# Altium schematic sheet 02_MCU.SchDoc(02_MCU)
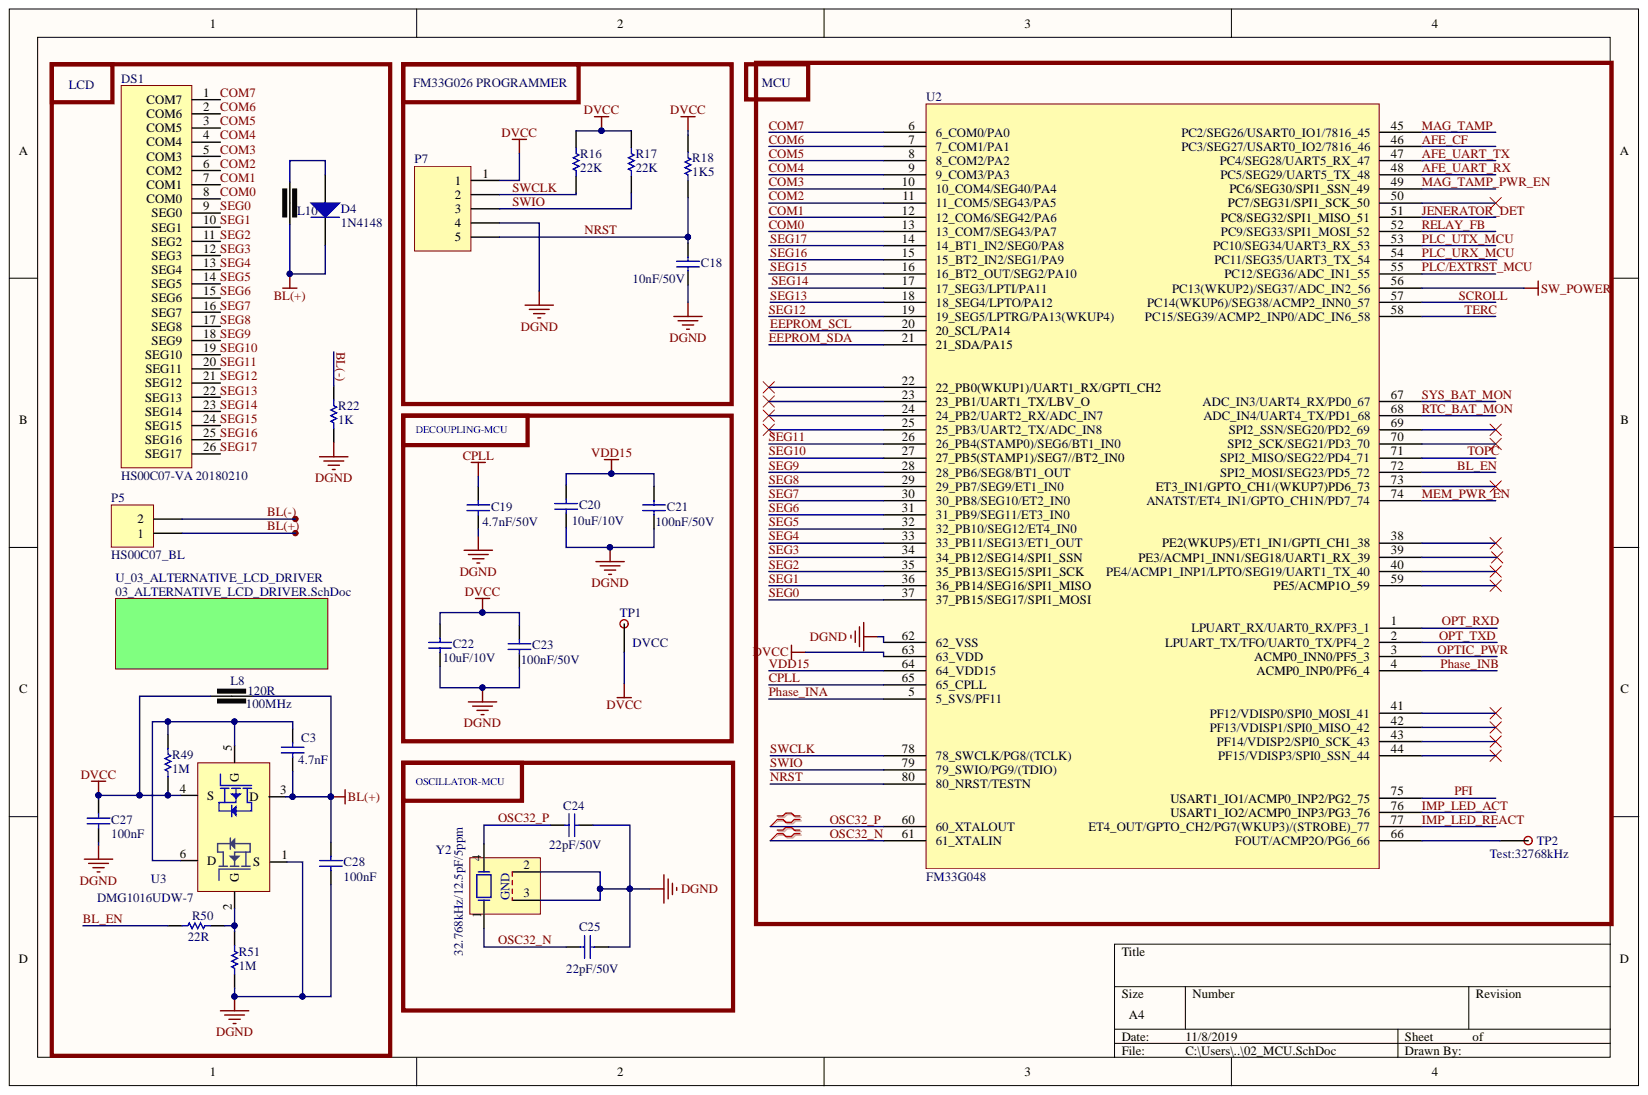
[diagram p0: 02_MCU.SchDoc]

## Parts
C3  Capacitance: 4.7nF  [CAP_0603_V1]  (hidden comment: CAP_SMD)
C18  10nF/50V  [CAP_0603_V1]
C19  4.7nF/50V  [CAP_0603_V1]
C20  10uF/10V  [CAP_0603_V1]
C21  100nF/50V  [CAP_0603_V1]
C22  10uF/10V  [CAP_0603_V1]
C23  100nF/50V  [CAP_0603_V1]
C24  22pF/50V  [CAP_0603_V1]
C25  22pF/50V  [CAP_0603_V1]
C27  Capacitance: 100nF  [CAP_0603_V1]  (hidden comment: CAP_SMD)
C28  Capacitance: 100nF  [CAP_0603_V1]  (hidden comment: CAP_SMD)
D4  004_Value: 1N4148  [SOD-123]  (hidden comment: DIODE)
DS1  value HS00C07-VA 20180210  [HS00C07-VA-20171019]  (hidden comment: HS00C07-VHB 20180830)
L8  Test Frequency: 100MHz  Impedance: 120R  [FERRITE-BEAD_0603_V1]  (hidden comment: FERRITE BEAD)
L10  FERRITE BEAD  [FERRITE-BEAD_0603_V1]  (value not drawn)
P5  value HS00C07_BL  [HS00C07-VA-20171019_BL]  (hidden comment: HEADER-2PIN)
P7  HEADER_1X5  [HEADER_5_PIN_2X3_V1_2.54]  (value not drawn)
R16  22K  [RES_0603_V1]
R17  22K  [RES_0603_V1]
R18  1K5  [RES_0603_V1]
R22  004_Value: 1K  [RES_1206_V1]  (hidden comment: RES_SMD)
R49  004_Value: 1M  [RES_0603_V1]  (hidden comment: RES_SMD)
R50  004_Value: 22R  [RES_0603_V1]  (hidden comment: RES_SMD)
R51  004_Value: 1M  [RES_0603_V1]  (hidden comment: RES_SMD)
TP1  004_Value: DVCC  [TEST-PAD_2.0X2.0_ROUND - No_3D_Step]  (hidden comment: TEST_POINT)
TP2  004_Value: Test:32768kHz  [TEST-PAD_2.0X2.0_ROUND - No_3D_Step]  (hidden comment: TEST_POINT)
U2  MCU, FUDAN, CORTEX-M0  [LQFP-80N]  (value not drawn)
U3  DMG1016UDW-7  [SOT363(TSSOP6)_TSOP65P210X110-6N]
Y2  004_Value: 32.768kHz/12.5pF/5ppm  [XTAL_THT_SMD_TUNING_FORK]  (hidden comment: R26-32.768)

## Nets
DGND: C18.1 C19.1 C20.1 C21.1 C22.1 C23.1 C24.2 C25.2 C27.1 C28.1 P7.4 R22.1 R51.1 U2.62(62_VSS) U3.1(S) Y2.2 Y2.3
DVCC: C22.2 C23.2 C27.2 L8.1 P7.1 R16.2 R17.2 R18.2 R49.1 TP1.1 U2.63(63_VDD) U3.4(S)
SW_POWER: U2.56(PC13(WKUP2)/SEG37/ADC_IN2_56)
AFE_CF: U2.46(PC3/SEG27/USART0_IO2/7816_46)
AFE_UART_RX: U2.48(PC5/SEG29/UART5_TX_48)
AFE_UART_TX: U2.47(PC4/SEG28/UART5_RX_47)
BL(+): C3.1 C28.2 D4.2 L8.2 L10.2 P5.1 U3.3(D)
BL(-): P5.2 R22.2
BL_EN: R50.1 U2.72(SPI2_MOSI/SEG23/PD5_72)
COM0: DS1.8(COM0) U2.13(13_COM7/SEG43/PA7)
COM1: DS1.7(COM1) U2.12(12_COM6/SEG42/PA6)
COM2: DS1.6(COM2) U2.11(11_COM5/SEG43/PA5)
COM3: DS1.5(COM3) U2.10(10_COM4/SEG40/PA4)
COM4: DS1.4(COM4) U2.9(9_COM3/PA3)
COM5: DS1.3(COM5) U2.8(8_COM2/PA2)
COM6: DS1.2(COM6) U2.7(7_COM1/PA1)
COM7: DS1.1(COM7) U2.6(6_COM0/PA0)
CPLL: C19.2 U2.65(65_CPLL)
EEPROM_SCL: U2.20(20_SCL/PA14)
EEPROM_SDA: U2.21(21_SDA/PA15)
IMP_LED_ACT: U2.76(USART1_IO2/ACMP0_INP3/PG3_76)
IMP_LED_REACT: U2.77(ET4_OUT/GPTO_CH2/PG7(WKUP3)/(STROBE)_77)
JENERATOR_DET: U2.51(PC8/SEG32/SPI1_MISO_51)
MAG_TAMP: U2.45(PC2/SEG26/USART0_IO1/7816_45)
MAG_TAMP_PWR_EN: U2.49(PC6/SEG30/SPI1_SSN_49)
MEM_PWR_EN: U2.74(ANATST/ET4_IN1/GPTO_CH1N/PD7_74)
NRST: C18.2 P7.5 R18.1 U2.80(80_NRST/TESTN)
OPT_RXD: U2.1(LPUART_RX/UART0_RX/PF3_1)
OPT_TXD: U2.2(LPUART_TX/TFO/UART0_TX/PF4_2)
OPTIC_PWR: U2.3(ACMP0_INN0/PF5_3)
OSC32_N: C25.1 U2.61(61_XTALIN) Y2.1
OSC32_P: C24.1 U2.60(60_XTALOUT) Y2.4
PFI: U2.75(USART1_IO1/ACMP0_INP2/PG2_75)
Phase_INA: U2.5(5_SVS/PF11)
Phase_INB: U2.4(ACMP0_INP0/PF6_4)
PLC/EXTRST_MCU: U2.55(PC12/SEG36/ADC_IN1_55)
PLC_URX_MCU: U2.54(PC11/SEG35/UART3_TX_54)
PLC_UTX_MCU: U2.53(PC10/SEG34/UART3_RX_53)
RELAY_FB: U2.52(PC9/SEG33/SPI1_MOSI_52)
RTC_BAT_MON: U2.68(ADC_IN4/UART4_TX/PD1_68)
SCROLL: U2.57(PC14(WKUP6)/SEG38/ACMP2_INN0_57)
SEG0: DS1.9(SEG0) U2.37(37_PB15/SEG17/SPI1_MOSI)
SEG1: DS1.10(SEG1) U2.36(36_PB14/SEG16/SPI1_MISO)
SEG2: DS1.11(SEG2) U2.35(35_PB13/SEG15/SPI1_SCK)
SEG3: DS1.12(SEG3) U2.34(34_PB12/SEG14/SPI1_SSN)
SEG4: DS1.13(SEG4) U2.33(33_PB11/SEG13/ET1_OUT)
SEG5: DS1.14(SEG5) U2.32(32_PB10/SEG12/ET4_IN0)
SEG6: DS1.15(SEG6) U2.31(31_PB9/SEG11/ET3_IN0)
SEG7: DS1.16(SEG7) U2.30(30_PB8/SEG10/ET2_IN0)
SEG8: DS1.17(SEG8) U2.29(29_PB7/SEG9/ET1_IN0)
SEG9: DS1.18(SEG9) U2.28(28_PB6/SEG8/BT1_OUT)
SEG10: DS1.19(SEG10) U2.27(27_PB5(STAMP1)/SEG7//BT2_IN0)
SEG11: DS1.20(SEG11) U2.26(26_PB4(STAMP0)/SEG6/BT1_IN0)
SEG12: DS1.21(SEG12) U2.19(19_SEG5/LPTRG/PA13(WKUP4))
SEG13: DS1.22(SEG13) U2.18(18_SEG4/LPTO/PA12)
SEG14: DS1.23(SEG14) U2.17(17_SEG3/LPTI/PA11)
SEG15: DS1.24(SEG15) U2.16(16_BT2_OUT/SEG2/PA10)
SEG16: DS1.25(SEG16) U2.15(15_BT2_IN2/SEG1/PA9)
SEG17: DS1.26(SEG17) U2.14(14_BT1_IN2/SEG0/PA8)
SWCLK: P7.2 R16.1 U2.78(78_SWCLK/PG8/(TCLK))
SWIO: P7.3 R17.1 U2.79(79_SWIO/PG9/(TDIO))
SYS_BAT_MON: U2.67(ADC_IN3/UART4_RX/PD0_67)
TERC: U2.58(PC15/SEG39/ACMP2_INP0/ADC_IN6_58)
TOPC: U2.71(SPI2_MISO/SEG22/PD4_71)
VDD15: C20.2 C21.2 U2.64(64_VDD15)
NetC3_2: C3.2 R49.2 U3.5(G) U3.6(D)
NetD4_1: D4.1 L10.1
NetR50_2: R50.2 R51.2 U3.2(G)
NetTP2_1: TP2.1 U2.66(FOUT/ACMP2O/PG6_66)

Not connected: U2 22(22_PB0(WKUP1)/UART1_RX/GPTI_CH2) 23(23_PB1/UART1_TX/LBV_O) 24(24_PB2/UART2_RX/ADC_IN7) 25(25_PB3/UART2_TX/ADC_IN8) 38(PE2(WKUP5)/ET1_IN1/GPTI_CH1_38) 39(PE3/ACMP1_INN1/SEG18/UART1_RX_39) 40(PE4/ACMP1_INP1/LPTO/SEG19/UART1_TX_40) 41(PF12/VDISP0/SPI0_MOSI_41) 42(PF13/VDISP1/SPI0_MISO_42) 43(PF14/VDISP2/SPI0_SCK_43) 44(PF15/VDISP3/SPI0_SSN_44) 50(PC7/SEG31/SPI1_SCK_50) 59(PE5/ACMP1O_59) 69(SPI2_SSN/SEG20/PD2_69) 70(SPI2_SCK/SEG21/PD3_70) 73(ET3_IN1/GPTO_CH1/(WKUP7)PD6_73)

## Library data of the parts
C3 = CAP_SMD: 017_Manufacturer-1 PN: CL10B472KB8SFNC; 016_Manufacturer-1: Samsung; Voltage Rating: 50V; Tolerance: 10%; Parameter Name: Tape and Reel; Dielectric: X7R; 006_SMT/THT: Surface Mount; 001_Description: CAP CER 4700PF 50V X7R 0603; Width: 0.031inch; Thickness: 0.035inch; Supplier Part Number 1: CL10B472KB8SFNC-REEL; Supplier 1: Future Electronics; Stock 1: 0; Radiation Hardening: No; Package Quantity: 1; Min Operating Temperature: -55°C; Max Operating Temperature: 125°C; Library Name: ElectricMeter.SchLib; Length: 0.063inch; Height: 0.8mm; Features: Soft Termination; Depth: 0.8mm; ComponentLink1URL: http://www.samsungsem.com/kr/support/product-search/mlcc/CL10B472KB8SFNC.jsp?src-supplier=Digi-Key; ComponentLink1Description: http://www.samsungsem.com/kr/support/product-search/mlcc/CL10B472KB8SFNC.jsp?src-supplier=Digi-Key; Component Kind: Standard; Case Code (Metric): 1608; Case Code (Imperial): 0603; Case/Package: 0603
C18 = CAP_SMD: MLCC, 10nF, 50V, X7R, 0603, %10; 017_Manufacturer-1 PN: GRM188R71H103KA01D; 016_Manufacturer-1: Murata; Tolerance: 10%; Parameter Name: Tape and Reel; Material: Ceramic; Insulation Resistance: 10GR; Width: 0.031inch; Voltage Rating (DC): 50V; Voltage Rating: 50V; Voltage: 50V; Thickness: 0.035inch; Termination: SMD/SMT; Supplier Part Number 1: GRM188R71H103KA01D; Supplier 1: Verical; Stock 1: 0; REACH SVHC: Unknown; Package Quantity: 1; Number of Pins: 2; Mount: Surface Mount; Min Operating Temperature: -55°C; Max Operating Temperature: 125°C; Library Name: SCH_LIB.SCHLIB; Length: 1.6mm; Lead Free: Lead Free; Height: 0.8mm; Dielectric Material: Ceramic; Dielectric: X7R; Depth: 0.8mm; ComponentLink6URL: https://produktinfo.conrad.com/datenblaetter/450000-474999/451715-da-01-en-VS_KONDENSATOR_0603.pdf?src-supplier=Conrad; ComponentLink6Description: https://produktinfo.conrad.com/datenblaetter/450000-474999/451715-da-01-en-VS_KONDENSATOR_0603.pdf?src-supplier=Conrad; ComponentLink5URL: https://www.distrelec.biz/Web/Downloads/_t/ds/murata_MLCC_eng_tds.pdf?mime=application%2Fpdf&src-supplier=Distrelec; ComponentLink5Description: https://www.distrelec.biz/Web/Downloads/_t/ds/murata_MLCC_eng_tds.pdf?mime=application%2Fpdf&src-supplier=Distrelec; ComponentLink4URL: http://www.farnell.com/datasheets/2047844.pdf?src-supplier=Element14; ComponentLink4Description: http://www.farnell.com/datasheets/2047844.pdf?src-supplier=Element14; ComponentLink3URL: http://www.farnell.com/datasheets/4360.pdf?src-supplier=Element14; ComponentLink3Description: http://www.farnell.com/datasheets/4360.pdf?src-supplier=Element14; ComponentLink2URL: http://uk.rs-online.com/webdocs/0030/0900766b8003061f.pdf?src-supplier=RSComponents; ComponentLink2Description: http://uk.rs-online.com/webdocs/0030/0900766b8003061f.pdf?src-supplier=RSComponents; ComponentLink1URL: https://www.murata.com/~/media/webrenewal/support/library/catalog/products/capacitor/mlcc/c02e.ashx?la=en-us&src-supplier=Digi-Key; ComponentLink1Description: https://www.murata.com/~/media/webrenewal/support/library/catalog/products/capacitor/mlcc/c02e.ashx?la=en-us&src-supplier=Digi-Key; Component Kind: Standard; Case Code (Metric): 1608; Case Code (Imperial): 0603; Case/Package: 0603; Capacitance: 10nF
C19 = CAP_SMD: MLCC, 4.7nF, 50V, X7R, 0603, %10; 017_Manufacturer-1 PN: CL10B472JB8NNNC; 016_Manufacturer-1: Samsung; Tolerance: 5%; Parameter Name: Tape and Reel; Material: Ceramic; Width: 0.031inch; Voltage Rating (DC): 50V; Voltage Rating: 50V; Voltage: 50V; Thickness: 0.035inch; Supplier Part Number 1: CL10B472JB8NNNC; Supplier 1: Arrow; Stock 1: 319584; Radiation Hardening: No; Pricing 1: 4000=0.0063, 8000=0.0057, 12000=0.0052, 1=0.0049, 25=0.0047 (USD); Package Quantity: 1; Mount: Surface Mount; Min Operating Temperature: -55°C; Max Operating Temperature: 125°C; Library Name: SCH_LIB.SCHLIB; Length: 1.6mm; Lead Free: Lead Free; Height: 0.8mm; Dielectric: X7R; Depth: 0.8mm; Contact Plating: Tin; ComponentLink5URL: https://produktinfo.conrad.com/datenblaetter/450000-474999/457717-da-01-en-VS_KONDENSATOR_0603.pdf?src-supplier=Conrad; ComponentLink5Description: https://produktinfo.conrad.com/datenblaetter/450000-474999/457717-da-01-en-VS_KONDENSATOR_0603.pdf?src-supplier=Conrad; ComponentLink4URL: https://www.distrelec.biz/Web/Downloads/_e/ng/MLCC_CatalogDownload2013_ENG.pdf?mime=application%2Fpdf&src-supplier=Distrelec; ComponentLink4Description: https://www.distrelec.biz/Web/Downloads/_e/ng/MLCC_CatalogDownload2013_ENG.pdf?mime=application%2Fpdf&src-supplier=Distrelec; ComponentLink3URL: http://www1.futureelectronics.com/doc/SAMSUNG%20PASSIVE/CL05B104KQ5NNNC.pdf?src-supplier=Future+Electronics; ComponentLink3Description: http://www1.futureelectronics.com/doc/SAMSUNG%20PASSIVE/CL05B104KQ5NNNC.pdf?src-supplier=Future+Electronics; ComponentLink2URL: https://www.verical.com/server-webapp/html/getDatasheet?partID=666231&src-supplier=Verical; ComponentLink2Description: https://www.verical.com/server-webapp/html/getDatasheet?partID=666231&src-supplier=Verical; ComponentLink1URL: https://media.digikey.com/pdf/Data%20Sheets/Samsung%20PDFs/CL_Series_MLCC_ds.pdf?src-supplier=Digi-Key; ComponentLink1Description: https://media.digikey.com/pdf/Data%20Sheets/Samsung%20PDFs/CL_Series_MLCC_ds.pdf?src-supplier=Digi-Key; Component Kind: Standard; Case Code (Metric): 1608; Case Code (Imperial): 0603; Case/Package: 0603; Capacitance: 4.7nF
C20 C22 = CAP_SMD: 10µF ±10% 10V Ceramic Capacitor X5R 0603 (1608 Metric); 017_Manufacturer-1 PN: GRM188R61A106KE69D; 016_Manufacturer-1: Murata; Parameter Name: Tape and Reel; Capacitance: 10uF; Width: 0.031inch; Voltage Rating (DC): 10V; Voltage Rating: 10V; Voltage: 10V; Tolerance: 10%; Thickness: 0.037inch; Supplier Part Number 1: GRM188R61A106KE69D; Supplier 1: Arrow; Stock 1: 2410; REACH SVHC: No SVHC; Pricing 1: 1=0.0335 (USD); Mount: Surface Mount; Min Operating Temperature: -55°C; Max Operating Temperature: 85°C; Library Name: SCH_LIB.SCHLIB; Length: 1.6mm; Lead Free: Lead Free; Height: 0.8mm; Dielectric: X5R; Depth: 0.8mm; Component Kind: Standard; Case Code (Metric): 1608; Case Code (Imperial): 0603; Case/Package: 0603
C21 C23 = CAP_SMD: CAP CER 0.1UF 50V X7R 0603; 017_Manufacturer-1 PN: CL10B104KB8NNNC; 016_Manufacturer-1: Samsung; Tolerance: 10%; Parameter Name: Tape and Reel; Material: Ceramic; Capacitance: 100nF; Width: 0.031inch; Voltage Rating (DC): 50V; Voltage Rating: 50V; Voltage: 50V; Thickness: 0.035inch; Supplier Part Number 1: CL10B104KB8NNNC; Radiation Hardening: No; Package Quantity: 1; Mount: Surface Mount; Min Operating Temperature: -55°C; Max Operating Temperature: 125°C; Library Name: SCH_LIB.SCHLIB; Length: 1.6mm; Lead Free: Lead Free; Height: 0.8mm; Dielectric: X7R; Depth: 0.8mm; Contact Plating: Tin; ComponentLink3URL: https://produktinfo.conrad.com/datenblaetter/450000-474999/456651-da-01-en-VS_KONDENSATOR_0605.pdf?src-supplier=Conrad; ComponentLink3Description: https://produktinfo.conrad.com/datenblaetter/450000-474999/456651-da-01-en-VS_KONDENSATOR_0605.pdf?src-supplier=Conrad; ComponentLink2URL: https://www.verical.com/server-webapp/html/getDatasheet?partID=89097&src-supplier=Verical; ComponentLink2Description: https://www.verical.com/server-webapp/html/getDatasheet?partID=89097&src-supplier=Verical; ComponentLink1URL: http://www.samsungsem.com/kr/support/product-search/mlcc/CL10B104KB8NNNC.jsp?src-supplier=Digi-Key; ComponentLink1Description: http://www.samsungsem.com/kr/support/product-search/mlcc/CL10B104KB8NNNC.jsp?src-supplier=Digi-Key; Component Kind: Standard; Case Code (Metric): 1608; Case Code (Imperial): 0603; Case/Package: 0603
  C21: Supplier 1: Arrow; Stock 1: 16987458; Pricing 1: 4000=0.0047, 8000=0.0043, 12000=0.0032, 1=0.0352, 10=0.0258, 25=0.0233, 100=0.02, 250=0.018 (USD)
  C23: Supplier 1: Arrow Europe; Stock 1: 0
C24 C25 = CAP_SMD: 22pF ±5% 50V Ceramic Capacitor C0G, NP0 0603 (1608 Metric); 017_Manufacturer-1 PN: GRM1885C1H220JA01D; 016_Manufacturer-1: Murata; Tolerance: 10%; Temperature Coefficient: 30ppm/°C; Parameter Name: Tape and Reel; Insulation Resistance: 10GR; Width: 0.031inch; Voltage Rating (DC): 50V; Voltage Rating: 50V; Voltage: 50V; Thickness: 0.035inch; Termination: SMD/SMT; Supplier Part Number 1: GRM1885C1H220JA01D**MULT; Supplier 1: Arrow; Stock 1: 0; REACH SVHC: No SVHC; Radiation Hardening: No; Package Quantity: 1; Number of Pins: 2; Mount: Surface Mount; Min Operating Temperature: -55°C; Max Operating Temperature: 125°C; Material: Ceramic; Library Name: SCH_LIB.SCHLIB; Length: 1.6mm; Lead Free: Lead Free; Height: 0.8mm; Dielectric Material: Ceramic; Dielectric: C0G; Depth: 0.8mm; ComponentLink4URL: http://uk.rs-online.com/webdocs/1423/0900766b81423d62.pdf?src-supplier=RSComponents; ComponentLink4Description: http://uk.rs-online.com/webdocs/1423/0900766b81423d62.pdf?src-supplier=RSComponents; ComponentLink3URL: http://components.arrow.com/part/dataview/39140722S3442535N2606?src-supplier=Arrow; ComponentLink3Description: http://components.arrow.com/part/dataview/39140722S3442535N2606?src-supplier=Arrow; ComponentLink2URL: https://www.distrelec.biz/Web/Downloads/_t/ds/murata_MLCC_eng_tds.pdf?mime=application%2Fpdf&src-supplier=Distrelec; ComponentLink2Description: https://www.distrelec.biz/Web/Downloads/_t/ds/murata_MLCC_eng_tds.pdf?mime=application%2Fpdf&src-supplier=Distrelec; ComponentLink1URL: https://www.murata.com/~/media/webrenewal/support/library/catalog/products/capacitor/mlcc/c02e.ashx?la=en-us&src-supplier=Digi-Key; ComponentLink1Description: https://www.murata.com/~/media/webrenewal/support/library/catalog/products/capacitor/mlcc/c02e.ashx?la=en-us&src-supplier=Digi-Key; Component Kind: Standard; Case Code (Metric): 1608; Case Code (Imperial): 0603; Case/Package: 0603; Capacitance: 22pF
C27 C28 = CAP_SMD: MLCC; 100nF; 50V; X7R; ±10%; SMD; 0603; -55÷125°C; 017_Manufacturer-1 PN: CL10B104KB8NNNC; 016_Manufacturer-1: Samsung; Parameter Name: Tape and Reel; Material: Ceramic; 006_SMT/THT: Surface Mount; 001_Description: Capacitor: ceramic; MLCC; 100nF; 50V; X7R; ±10%; SMD; 0603; -55÷125°C; Width: 0.031inch; Voltage Rating (DC): 50V; Voltage Rating: 50V; Voltage: 50V; Tolerance: 10%; Thickness: 0.035inch; Supplier Part Number 1: 766-5443; Supplier 1: RSComponents; Stock 1: 0; Radiation Hardening: No; Package Quantity: 1; Min Operating Temperature: -55°C; Max Operating Temperature: 125°C; Library Name: ElectricMeter.SchLib; Length: 1.6mm; Lead Free: Lead Free; Height: 0.8mm; Dielectric: X7R; Depth: 0.8mm; Contact Plating: Tin; ComponentLink3URL: https://produktinfo.conrad.com/datenblaetter/450000-474999/456651-da-01-en-VS_KONDENSATOR_0605.pdf?src-supplier=Conrad; ComponentLink3Description: https://produktinfo.conrad.com/datenblaetter/450000-474999/456651-da-01-en-VS_KONDENSATOR_0605.pdf?src-supplier=Conrad; ComponentLink2URL: https://www.verical.com/server-webapp/html/getDatasheet?partID=89097&src-supplier=Verical; ComponentLink2Description: https://www.verical.com/server-webapp/html/getDatasheet?partID=89097&src-supplier=Verical; ComponentLink1URL: http://www.samsungsem.com/kr/support/product-search/mlcc/CL10B104KB8NNNC.jsp?src-supplier=Digi-Key; ComponentLink1Description: http://www.samsungsem.com/kr/support/product-search/mlcc/CL10B104KB8NNNC.jsp?src-supplier=Digi-Key; Component Kind: Standard; Case Code (Metric): 1608; Case Code (Imperial): 0603; Case/Package: 0603
D4 = DIODE: Diode, Fast Switching, 100V, 300mA, SOD123; 019_Manufacturer-1 Digi-Key PN: 1N4148W-FDITR-ND; 017_Manufacturer-1 PN: 1N4148W-7-F; 016_Manufacturer-1: Diodes; 007_Status: MP; 006_SMT/THT: SMT; 005_Process: SMD_Mounter; Library Name: BM.10.LC02.SCHLIB; Component Kind: Standard; 008_Package: SOD123; 001_Designation: Diode
DS1 = HS00C07-VA-20171019: LCD, Glass, HS00C07-VHB 20180830 foam 2.5mm; Manufacturer: HT Display; SMT/THT: THT; Model 4: BM32; Model 3: BM31; Model 2: BM22; Model 1: BM21; Status: MP; Project: -; Manufacturer PN 2: -; Manufacturer PN: HS00C07-VHB 20180830; Manufacturer 2: -; Library Name: ElectricMeter.SchLib; Designation: LCD; Component Kind: Standard
L8 = FERRITE BEAD: Inductor, Ferrite Bead, 120R@100Mhz, Power Line, 2A; 048_Manufacturer-2 PN: CIC10P121NC; 047_Manufacturer-2: SAMSUNG; 017_Manufacturer-1 PN: BLM18PG121SN1D; 016_Manufacturer-1: Murata; Tolerance: 25%; Shielding: Unshielded; Parameter Name: Tape and Reel; Number of Lines: 1; Material: Steel,Ferrite; DC Resistance (DCR): 50mR; 001_Description: MURATA - BLM18PG121SN1D - FERRITE BEAD, 120 OHM, 2A, 0603; Width: 0.8mm; Termination: SMD/SMT; Supplier Part Number 1: 724-1380; Supplier 1: RSComponents; Stock 1: 0; REACH SVHC: No SVHC; Radiation Hardening: No; Package Quantity: 1; Number of Pins: 2; Number of Circuits: 1; Min Operating Temperature: -55°C; Max Operating Temperature: 125°C; Max DC Current: 2A; Max Current Rating: 2A; Library Name: ElectricMeter.SchLib; Length: 1.6mm; Lead Free: Lead Free; Height: 0.8mm; Frequency: 100MHz; Depth: 0.8mm; DC Current: 2A; Current Rating: 2A; Core Material: Ferrite; ComponentLink11URL: http://www.produktinfo.conrad.com/datenblaetter/1100000-1199999/001172297-da-01-en-DROSSEL_0603_120R_1_6X0_8MM_4000ST.pdf?src-supplier=Conrad; ComponentLink11Description: http://www.produktinfo.conrad.com/datenblaetter/1100000-1199999/001172297-da-01-en-DROSSEL_0603_120R_1_6X0_8MM_4000ST.pdf?src-supplier=Conrad; ComponentLink10URL: http://www.produktinfo.conrad.com/datenblaetter/425000-449999/442365-da-01-en-CHIP_DROSSEL_0603_120R_2000MA_1_6X0_8MM.pdf?src-supplier=Conrad; ComponentLink10Description: http://www.produktinfo.conrad.com/datenblaetter/425000-449999/442365-da-01-en-CHIP_DROSSEL_0603_120R_2000MA_1_6X0_8MM.pdf?src-supplier=Conrad; ComponentLink9URL: http://www.farnell.com/datasheets/1740433.pdf?src-supplier=Avnet+Europe; ComponentLink9Description: http://www.farnell.com/datasheets/1740433.pdf?src-supplier=Avnet+Europe; ComponentLink8URL: http://www.farnell.com/datasheets/1740433.pdf?src-supplier=Jameco; ComponentLink8Description: http://www.farnell.com/datasheets/1740433.pdf?src-supplier=Jameco; ComponentLink7URL: http://uk.rs-online.com/webdocs/13d6/0900766b813d688c.pdf?src-supplier=RSComponents; ComponentLink7Description: http://uk.rs-online.com/webdocs/13d6/0900766b813d688c.pdf?src-supplier=RSComponents; ComponentLink6URL: http://www.farnell.com/datasheets/1740433.pdf?src-supplier=Distrelec; ComponentLink6Description: http://www.farnell.com/datasheets/1740433.pdf?src-supplier=Distrelec; ComponentLink5URL: http://www.farnell.com/datasheets/1740433.pdf?src-supplier=Arrow; ComponentLink5Description: http://www.farnell.com/datasheets/1740433.pdf?src-supplier=Arrow; ComponentLink4URL: https://www.murata.com/en-us/products/productdata/8796738650142/ENFA0003.pdf?src-supplier=Digi-Key; ComponentLink4Description: https://www.murata.com/en-us/products/productdata/8796738650142/ENFA0003.pdf?src-supplier=Digi-Key; ComponentLink3URL: http://www.farnell.com/datasheets/1740433.pdf?src-supplier=Element14; ComponentLink3Description: http://www.farnell.com/datasheets/1740433.pdf?src-supplier=Element14; ComponentLink2URL: http://www.farnell.com/datasheets/1740433.pdf?src-supplier=Farnell; ComponentLink2Description: http://www.farnell.com/datasheets/1740433.pdf?src-supplier=Farnell; ComponentLink1URL: http://www.farnell.com/datasheets/1740433.pdf?src-supplier=Avnet; ComponentLink1Description: http://www.farnell.com/datasheets/1740433.pdf?src-supplier=Avnet; Component Kind: Standard; Case Code (Metric): 1608; Case Code (Imperial): 0603; Case/Package: 0603
L10 = BLM18PG121SN1D: Inductor, Ferrite Bead, 120R@100Mhz, Power Line, 2A; 016_Manufacturer-1: Murata; Tolerance: 25%; Test Frequency: 100MHz; Shielding: Unshielded; Parameter Name: Tape and Reel; Number of Lines: 1; Material: Steel,Ferrite; Impedance: 120R; DC Resistance (DCR): 50mR; 001_Description: MURATA - BLM18PG121SN1D - FERRITE BEAD, 120 OHM, 2A, 0603; Width: 0.8mm; Termination: SMD/SMT; Supplier Part Number 1: 724-1380; Supplier 1: RSComponents; Stock 1: 0; REACH SVHC: No SVHC; Radiation Hardening: No; Package Quantity: 1; Number of Pins: 2; Number of Circuits: 1; Min Operating Temperature: -55°C; Max Operating Temperature: 125°C; Max DC Current: 2A; Max Current Rating: 2A; Library Name: ElectricMeter.SchLib; Length: 1.6mm; Lead Free: Lead Free; Height: 0.8mm; Frequency: 100MHz; Depth: 0.8mm; DC Current: 2A; Current Rating: 2A; Core Material: Ferrite; ComponentLink11URL: http://www.produktinfo.conrad.com/datenblaetter/1100000-1199999/001172297-da-01-en-DROSSEL_0603_120R_1_6X0_8MM_4000ST.pdf?src-supplier=Conrad; ComponentLink11Description: http://www.produktinfo.conrad.com/datenblaetter/1100000-1199999/001172297-da-01-en-DROSSEL_0603_120R_1_6X0_8MM_4000ST.pdf?src-supplier=Conrad; ComponentLink10URL: http://www.produktinfo.conrad.com/datenblaetter/425000-449999/442365-da-01-en-CHIP_DROSSEL_0603_120R_2000MA_1_6X0_8MM.pdf?src-supplier=Conrad; ComponentLink10Description: http://www.produktinfo.conrad.com/datenblaetter/425000-449999/442365-da-01-en-CHIP_DROSSEL_0603_120R_2000MA_1_6X0_8MM.pdf?src-supplier=Conrad; ComponentLink9URL: http://www.farnell.com/datasheets/1740433.pdf?src-supplier=Avnet+Europe; ComponentLink9Description: http://www.farnell.com/datasheets/1740433.pdf?src-supplier=Avnet+Europe; ComponentLink8URL: http://www.farnell.com/datasheets/1740433.pdf?src-supplier=Jameco; ComponentLink8Description: http://www.farnell.com/datasheets/1740433.pdf?src-supplier=Jameco; ComponentLink7URL: http://uk.rs-online.com/webdocs/13d6/0900766b813d688c.pdf?src-supplier=RSComponents; ComponentLink7Description: http://uk.rs-online.com/webdocs/13d6/0900766b813d688c.pdf?src-supplier=RSComponents; ComponentLink6URL: http://www.farnell.com/datasheets/1740433.pdf?src-supplier=Distrelec; ComponentLink6Description: http://www.farnell.com/datasheets/1740433.pdf?src-supplier=Distrelec; ComponentLink5URL: http://www.farnell.com/datasheets/1740433.pdf?src-supplier=Arrow; ComponentLink5Description: http://www.farnell.com/datasheets/1740433.pdf?src-supplier=Arrow; ComponentLink4URL: https://www.murata.com/en-us/products/productdata/8796738650142/ENFA0003.pdf?src-supplier=Digi-Key; ComponentLink4Description: https://www.murata.com/en-us/products/productdata/8796738650142/ENFA0003.pdf?src-supplier=Digi-Key; ComponentLink3URL: http://www.farnell.com/datasheets/1740433.pdf?src-supplier=Element14; ComponentLink3Description: http://www.farnell.com/datasheets/1740433.pdf?src-supplier=Element14; ComponentLink2URL: http://www.farnell.com/datasheets/1740433.pdf?src-supplier=Farnell; ComponentLink2Description: http://www.farnell.com/datasheets/1740433.pdf?src-supplier=Farnell; ComponentLink1URL: http://www.farnell.com/datasheets/1740433.pdf?src-supplier=Avnet; ComponentLink1Description: http://www.farnell.com/datasheets/1740433.pdf?src-supplier=Avnet; Component Kind: Standard; Case Code (Metric): 1608; Case Code (Imperial): 0603; Case/Package: 0603; 017_Manufacturer-1 PN: BLM18PG121SN1D
P5 = HEADER-2PIN: Optic, Backlight; SMT/THT: THT; Model 4: BM32; Model 3: BM31; Model 2: BM22; Model 1: BM21; Designation: Connector; Status: DU; Project: -; Manufacturer PN 2: -; Manufacturer PN: -; Manufacturer 2: -; Manufacturer: -; Library Name: HW.SchLib; Component Kind: Standard
P7 = HEADER_1X5: FM33G026 PROGRAMMER; 001_Designation: Header; Library Name: SCH_LIB.SCHLIB; Component Kind: Standard; 007_Status: -; 006_SMT/THT: -; 005_Process: -; 004_Value: FM33G026 PROGRAMMER; 003_Remark: -
R16 R17 = RC0603FR-0722KL: RES SMD 22K OHM 1% 1/10W 0603; 016_Manufacturer-1: Yageo; Voltage Rating (DC): 50V; Temperature Coefficient: 100ppm/°C; Resistance: 22kR; Power Rating: 100mW; Parameter Name: Tape and Reel; Width: 0.031inch; Voltage Rating: 50V; Tolerance: 1%; Termination: SMD/SMT; Supplier Part Number 1: RC0603FR-07 22KL; Supplier 1: Verical; Stock 1: 0; REACH SVHC: No SVHC; Package Quantity: 1; Number of Terminations: 2; Number of Pins: 2; Min Operating Temperature: -55°C; Military Standard: Not; Max Power Dissipation: 100mW; Max Operating Temperature: 155°C; Library Name: ElectricMeter.SchLib; Length: 1.6mm; Lead Free: Lead Free; Height: 0.022inch; Features: Moisture Resistant; Depth: 0.8mm; Composition: Thick Film; ComponentLink6URL: http://www.farnell.com/datasheets/1868787.pdf?src-supplier=Element14; ComponentLink6Description: http://www.farnell.com/datasheets/1868787.pdf?src-supplier=Element14; ComponentLink5URL: http://www.farnell.com/datasheets/443971.pdf?src-supplier=Element14; ComponentLink5Description: http://www.farnell.com/datasheets/443971.pdf?src-supplier=Element14; ComponentLink4URL: http://www.farnell.com/datasheets/1686128.pdf?src-supplier=Element14; ComponentLink4Description: http://www.farnell.com/datasheets/1686128.pdf?src-supplier=Element14; ComponentLink3URL: https://www.verical.com/server-webapp/html/getDatasheet?partID=413247&src-supplier=Verical; ComponentLink3Description: https://www.verical.com/server-webapp/html/getDatasheet?partID=413247&src-supplier=Verical; ComponentLink2URL: http://www.yageo.com/documents/recent/PYu-RC_Group_51_RoHS_L_10.pdf?src-supplier=Digi-Key; ComponentLink2Description: http://www.yageo.com/documents/recent/PYu-RC_Group_51_RoHS_L_10.pdf?src-supplier=Digi-Key; ComponentLink1URL: http://documents.rs-components.com/techlib://itc_doclist_GB.htm?stockno=5050325&src-supplier=RSComponents; ComponentLink1Description: http://documents.rs-components.com/techlib://itc_doclist_GB.htm?stockno=5050325&src-supplier=RSComponents; Component Kind: Standard; Case Code (Metric): 1608; Case Code (Imperial): 0603; Case/Package: 0603; 017_Manufacturer-1 PN: RC0603FR-0722KL
R18 = RC0603FR-071K5L: RES SMD 1.5K OHM 1% 1/10W 0603; 016_Manufacturer-1: Yageo; Voltage Rating (DC): 50V; Temperature Coefficient: 100ppm/°C; Resistance: 1.5kR; Power Rating: 100mW; Width: 0.031inch; Voltage Rating: 50V; Tolerance: 1%; Termination: SMD/SMT; Supplier Part Number 1: RC0603FR-071K5L; Supplier 1: Quest; Stock 1: 76200; REACH SVHC: No SVHC; Pricing 1: 1=0.0135, 371=0.009, 2223=0.0045, 11112=0.0014 (USD); Package Quantity: 1; Number of Terminations: 2; Number of Pins: 2; Min Operating Temperature: -55°C; Military Standard: Not; Max Power Dissipation: 100mW; Max Operating Temperature: 155°C; Library Name: ElectricMeter.SchLib; Length: 0.063inch; Lead Free: Lead Free; Height: 0.022inch; Features: Moisture Resistant; Depth: 0.8mm; Composition: Thick Film; ComponentLink2URL: http://www.farnell.com/datasheets/1868787.pdf?src-supplier=Element14; ComponentLink2Description: http://www.farnell.com/datasheets/1868787.pdf?src-supplier=Element14; ComponentLink1URL: http://www.yageo.com/documents/recent/PYu-RC_Group_51_RoHS_L_10.pdf?src-supplier=Digi-Key; ComponentLink1Description: http://www.yageo.com/documents/recent/PYu-RC_Group_51_RoHS_L_10.pdf?src-supplier=Digi-Key; Component Kind: Standard; Case Code (Metric): 1608; Case Code (Imperial): 0603; Case/Package: 0603; 017_Manufacturer-1 PN: RC0603FR-071K5L
R22 = RES_SMD: Chip Resistor, 1K, 1/4W, 1%, 1206; 017_Manufacturer-1 PN: 1206W4F1001T5E; 016_Manufacturer-1: Uniohm; Library Name: SCH_LIB.SCHLIB; Component Kind: Standard
R49 R51 = RES_SMD: 017_Manufacturer-1 PN: 0603SAJ0105TDE; 016_Manufacturer-1: Royalohm; Library Name: ElectricMeter.SchLib; Component Kind: Standard
R50 = RES_SMD: 017_Manufacturer-1 PN: 0603SAJ0220T5E; 016_Manufacturer-1: Royal Electronic Factory; 001_Description: Resistor: thick film; SMD; 0603; 22O; 0.1W; ±5%; -55÷125°C; Supplier Part Number 1: 0603SAJ0220T5E; Supplier 1: TME; Stock 1: 0; Pricing 1: 5000=0.00135, 15000=0.00114, 50000=0.00104 (USD); Library Name: ElectricMeter.SchLib; Component Kind: Standard
TP1 TP2 = TEST_POINT: Test Point, 2mm; 007_Status: TP; 006_SMT/THT: SMT; Component Kind: Standard; 008_Package: -; 005_Process: Test Point; 003_Remark: -; 001_Designation: Test Point
  TP1: Library Name: ElectricMeter.SchLib
  TP2: Library Name: SCH_LIB.SCHLIB
U2 = FM33G048: MCU, Cortex-M0, 256KB Flash, 24KB RAM, LQFP80; 025_Manufacturer-1 Distributor Unit Price (USD): 0.42; 016_Manufacturer-1: Fudan Microelectronics; 007_Status: MP; 006_SMT/THT: SMT; 005_Process: SMD_Mounter; Library Name: ElectricMeter.SchLib; Component Kind: Standard; 017_Manufacturer-1 PN: FM33G048; 008_Package: LQFP80; 004_Value: FM33G048; 003_Remark: -; 001_Designation: MCU
U3 = DMG1016UDW-7: MOSFET 20V Vdss 6V VGSS Complementary Pair; 016_Manufacturer-1: Diodes Zetex; Turn-On Delay Time: 5.1ns; Turn-Off Delay Time: 26.7ns; Threshold Voltage: 1V; Rise Time: 7.4ns; Rds On Max: 450mR; Power Dissipation: 330mW; Parameter Name: Tape and Reel; On-State Resistance: 750mR; Number of Elements: 2; Input Capacitance: 60.67pF; FET Type: N and P-Channel; Fall Time: 12.3ns; Drain to Source Resistance: 1.05R; Continuous Drain Current (ID): 845mA; Width: 1.35mm; Weight: 0.000212oz; Supplier Part Number 1: DMG1016UDW-7DIDKR-ND; Supplier 1: Digi-Key; Stock 1: 0; REACH SVHC: No SVHC; Radiation Hardening: No; Pricing 1: 1=0.57, 10=0.408, 100=0.2402, 500=0.13596, 1000=0.10424 (USD); Package Quantity: 3000; Number of Pins: 6; Number of Channels: 2; Mount: Surface Mount; Min Operating Temperature: -55°C; Max Power Dissipation: 330mW; Max Operating Temperature: 150°C; Library Name: ElectricMeter.SchLib; Length: 2.2mm; Lead Free: Lead Free; Height: 1mm; Gate to Source Voltage (Vgs): 6V; Drain to Source Voltage (Vdss): 20V; Drain to Source Breakdown Voltage: 20V; Contact Plating: Matte,Tin; ComponentLink4URL: http://www.mouser.com/ds/2/115/ds31860-67411.pdf; ComponentLink4Description: http://www.mouser.com/ds/2/115/ds31860-67411.pdf; ComponentLink3URL: http://www.diodes.com/_files/datasheets/ds31860.pdf; ComponentLink3Description: http://www.diodes.com/_files/datasheets/ds31860.pdf; ComponentLink2URL: https://www.diodes.com/assets/Datasheets/ds31860.pdf; ComponentLink2Description: https://www.diodes.com/assets/Datasheets/ds31860.pdf; ComponentLink1URL: http://www.diodes.com//datasheets/ds31860.pdf; ComponentLink1Description: http://www.diodes.com//datasheets/ds31860.pdf; Component Kind: Standard; Case/Package: SOT-363; 017_Manufacturer-1 PN: DMG1016UDW-7
Y2 = XTAL_4-PINS_SMD: Crystal, Tuning Fork, THT&SMD, 32768Hz, 12.5pF, 5ppm; 048_Manufacturer-2 PN: Q 0,032768-MMTF32-12,5-5-T1-LF; 047_Manufacturer-2: Jauch; 017_Manufacturer-1 PN: SSP-T7-F (32.768Khz-12.5pf-5ppm); 016_Manufacturer-1: Seiko; 007_Status: MP; 006_SMT/THT: SMT; 005_Process: SMD_Mounter; 001_Designation: Crystal Oscillator; Library Name: SCH_LIB.SCHLIB; Component Kind: Standard; 050_Manufacturer-2 Digi-Key PN: -; 019_Manufacturer-1 Digi-Key PN: -; 008_Package: -; 003_Remark: -
